# Altium schematic sheet SH4_BI-Gr_Potentiostat_D2AnA2D_R0.05.00.SchDoc(SH4_BI-Gr_Potentiostat_D2AnA2D_R0.05.00)
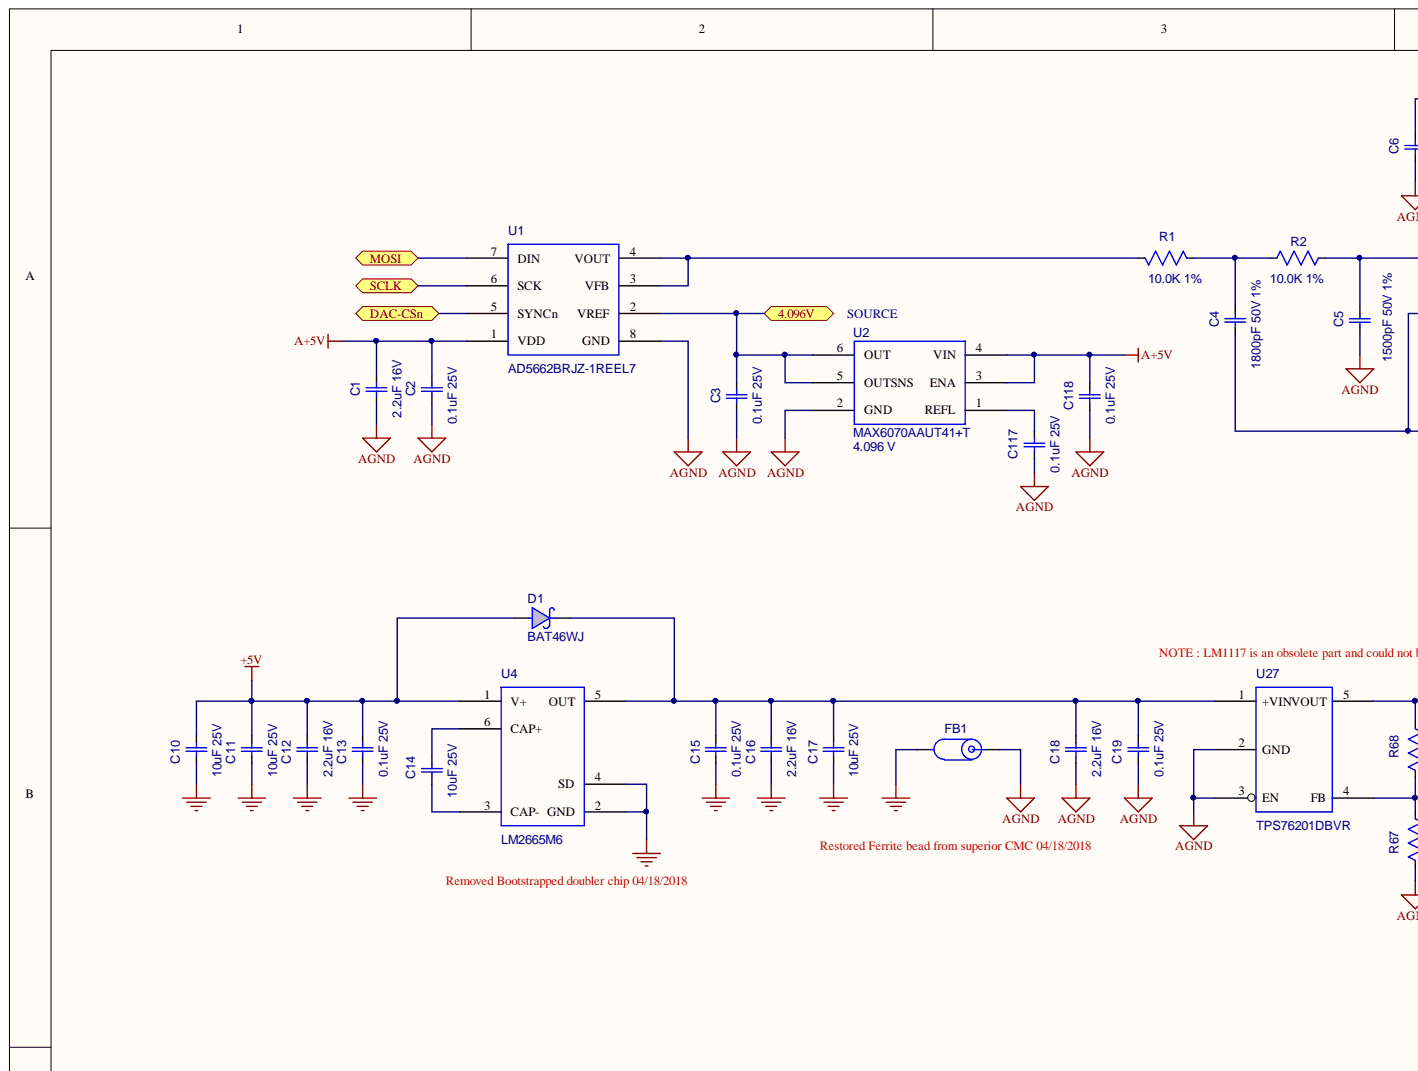
[diagram p0: SH4_BI-Gr_Potentiostat_D2AnA2D_R0.05.00.SchDoc, top left]
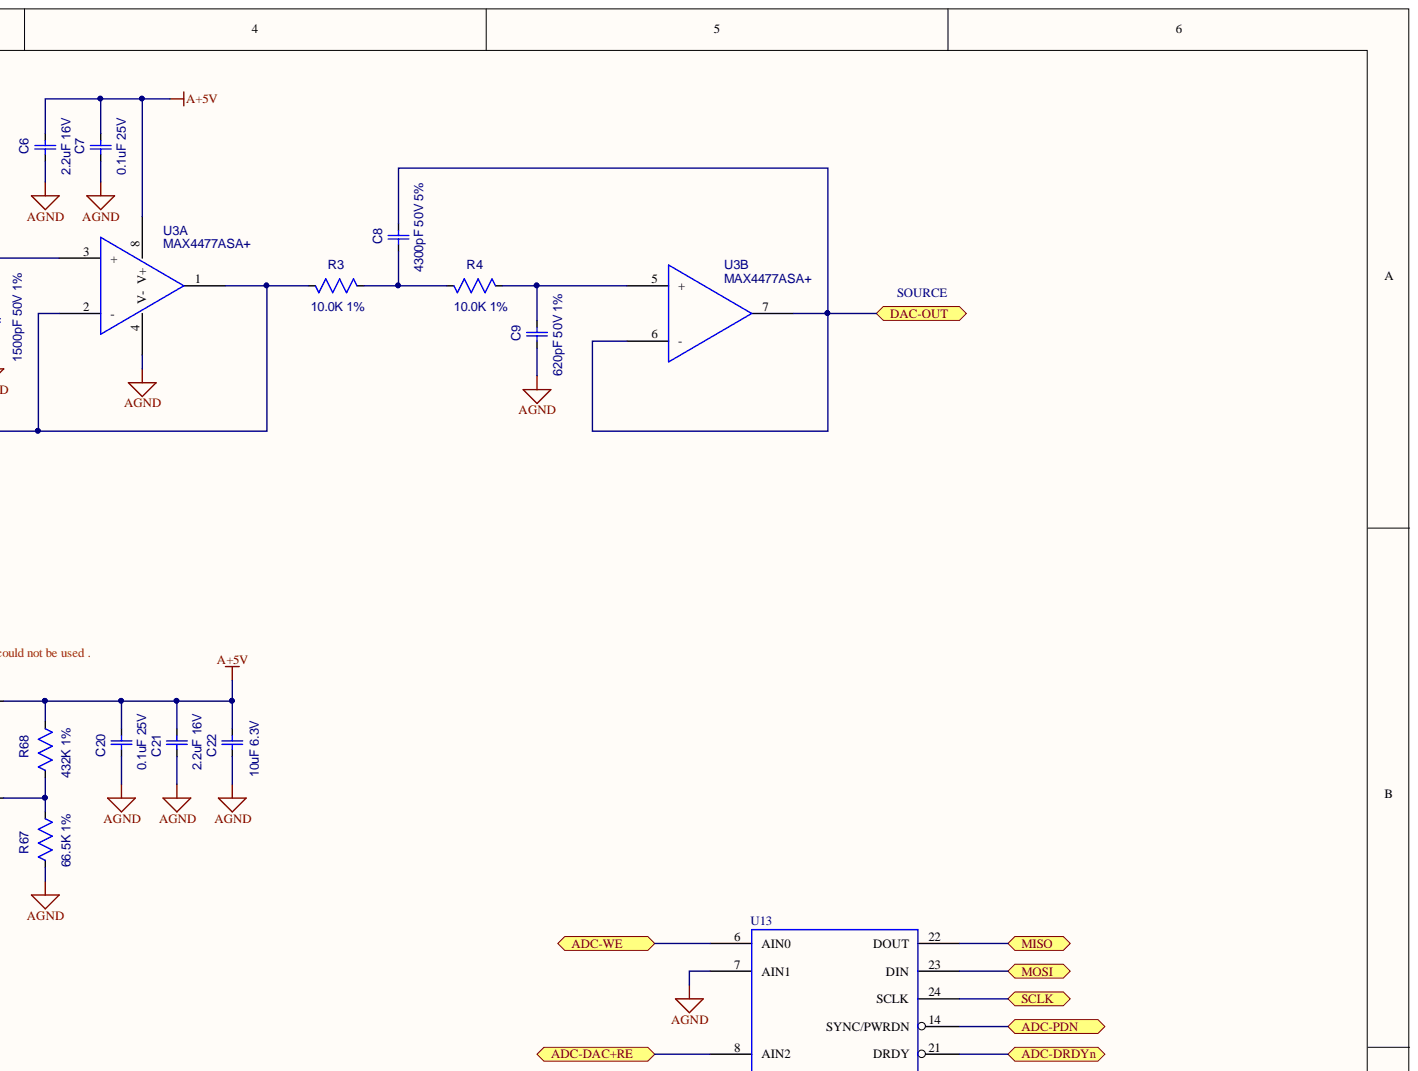
[diagram p1: SH4_BI-Gr_Potentiostat_D2AnA2D_R0.05.00.SchDoc, top right]
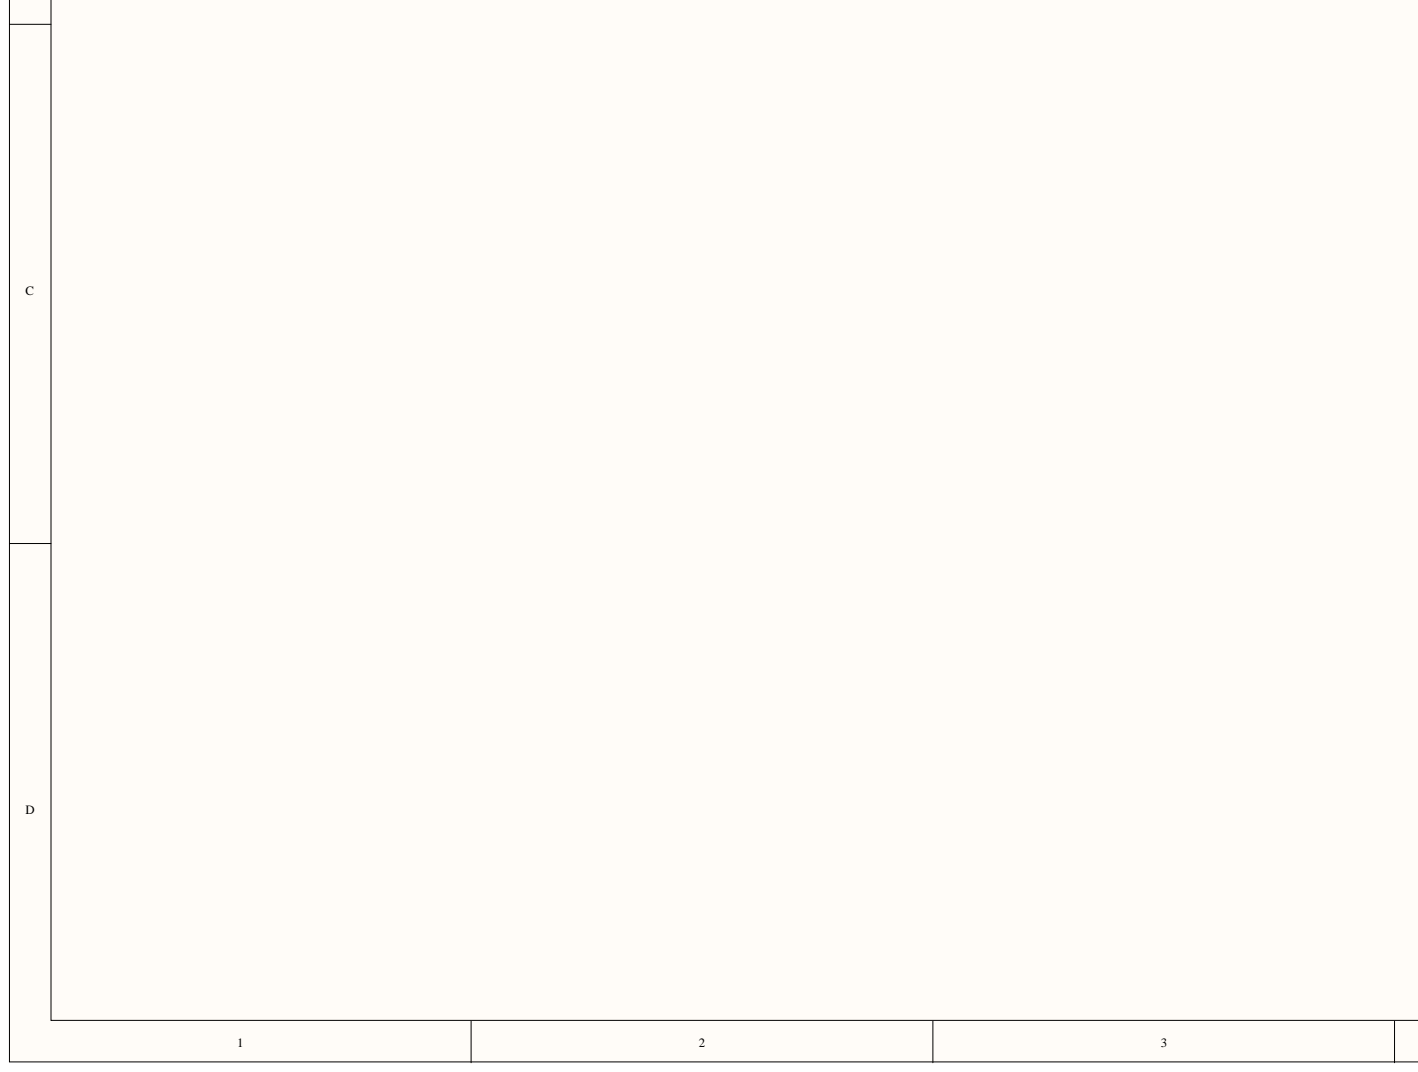
[diagram p2: SH4_BI-Gr_Potentiostat_D2AnA2D_R0.05.00.SchDoc, bottom left]
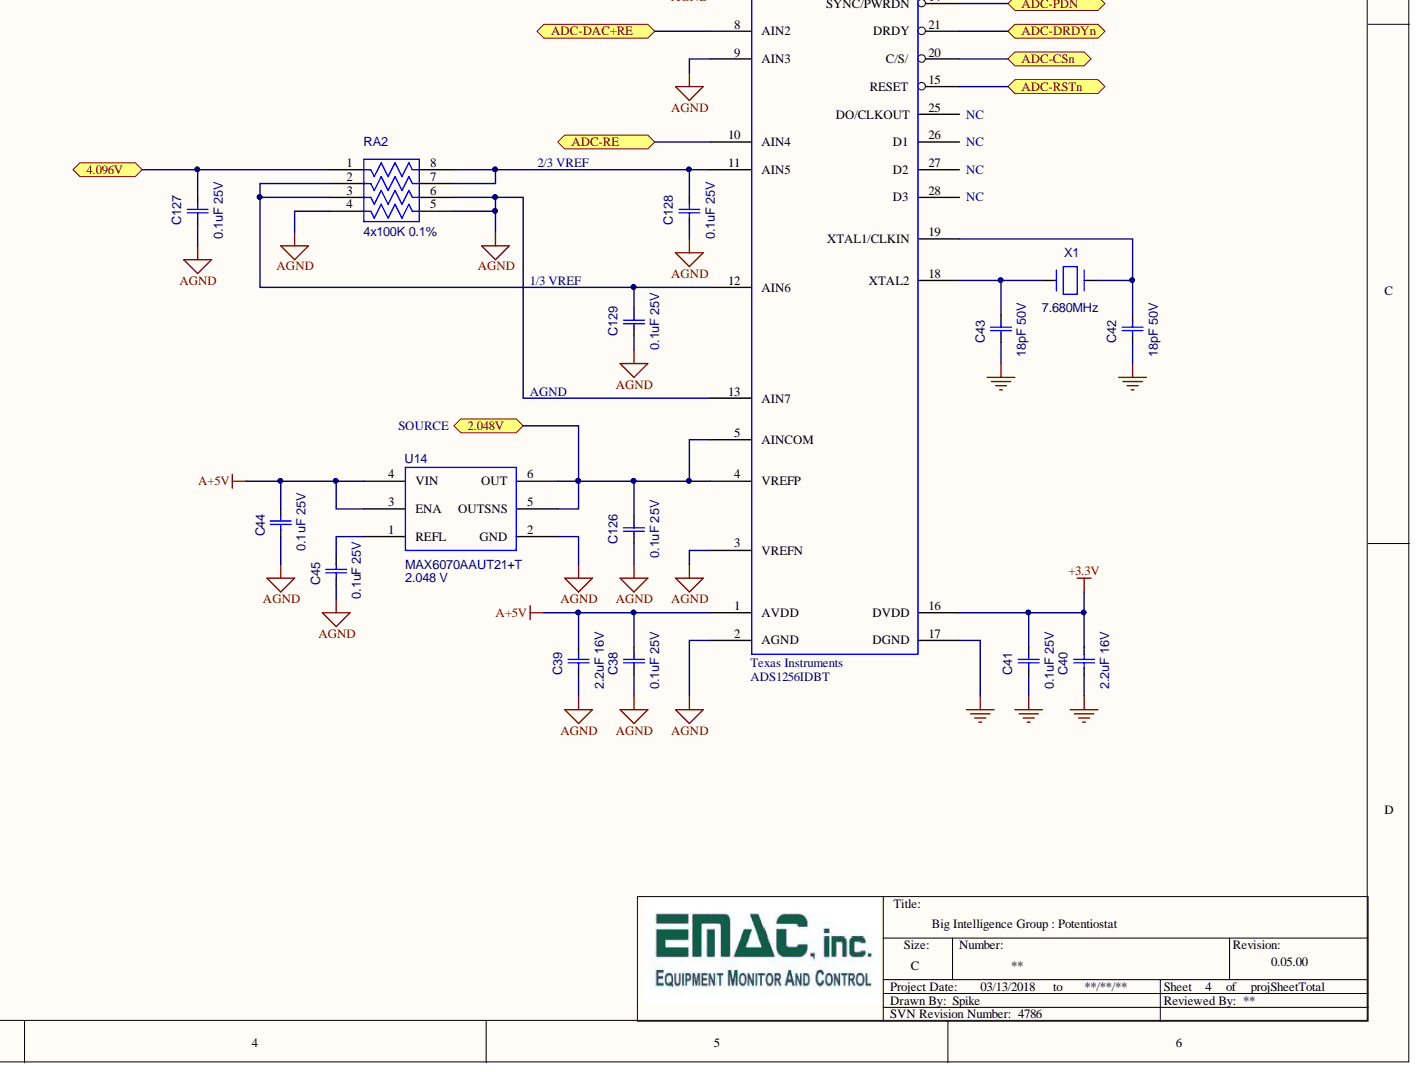
[diagram p3: SH4_BI-Gr_Potentiostat_D2AnA2D_R0.05.00.SchDoc, bottom right]

## Parts
C1  2.2uF 16V  value 2.2uF  Notes: 16V  [CC0603_IPC_L]
C2  0.1uF 25V  Notes: 25V  [CC0603_IPC_L]
C3  0.1uF 25V  Notes: 25V  [CC0603_IPC_L]
C4  1800pF 50V 1%  value 1800pF  Notes: 50V  [CC0603_IPC_L]
C5  1500pF 50V 1%  value 1500pF  Notes: 50V  [CC0603_IPC_L]
C6  2.2uF 16V  value 2.2uF  Notes: 16V  [CC0603_IPC_L]
C7  0.1uF 25V  Notes: 25V  [CC0603_IPC_L]
C8  4300pF 50V 5%  value 4300pF  Notes: 50V  [CC0603_IPC_L]
C9  620pF 50V 1%  value 620pF  Notes: 50V  [CC0603_IPC_L]
C10  10uF 25V  value 10uF  Notes: 25V  [CC0805_IPC_L]
C11  10uF 25V  value 10uF  Notes: 25V  [CC0805_IPC_L]
C12  2.2uF 16V  value 2.2uF  [CC0603_IPC_L]
C13  0.1uF 25V  Notes: 25V  [CC0603_IPC_L]
C14  10uF 25V  value 10uF  [CC0805_IPC_L]
C15  0.1uF 25V  Notes: 25V  [CC0603_IPC_L]
C16  2.2uF 16V  value 2.2uF  Notes: 16V  [CC0603_IPC_L]
C17  10uF 25V  value 10uF  Notes: 25V  [CC0805_IPC_L]
C18  2.2uF 16V  value 2.2uF  Notes: 16V  [CC0603_IPC_L]
C19  0.1uF 25V  Notes: 25V  [CC0603_IPC_L]
C20  0.1uF 25V  Notes: 25V  [CC0603_IPC_L]
C21  2.2uF 16V  value 2.2uF  Notes: 16V  [CC0603_IPC_L]
C22  10uF 6.3V  value 10uF  Notes: 6.3V  [CC0603_IPC_L]
C38  0.1uF 25V  Notes: 25V  [CC0603_IPC_L]
C39  2.2uF 16V  value 2.2uF  Notes: 16V  [CC0603_IPC_L]
C40  2.2uF 16V  value 2.2uF  Notes: 16V  [CC0603_IPC_L]
C41  0.1uF 25V  Notes: 25V  [CC0603_IPC_L]
C42  18pF 50V  value 18pF  Notes: 50V  [CC0603_IPC_L]
C43  18pF 50V  value 18pF  Notes: 50V  [CC0603_IPC_L]
C44  0.1uF 25V  Notes: 25V  [CC0603_IPC_L]
C45  0.1uF 25V  Notes: 25V  [CC0603_IPC_L]
C117  0.1uF 25V  Notes: 25V  [CC0603_IPC_L]
C118  0.1uF 25V  Notes: 25V  [CC0603_IPC_L]
C126  0.1uF 25V  Notes: 25V  [CC0603_IPC_L]
C127  0.1uF 25V  Notes: 25V  [CC0603_IPC_L]
C128  0.1uF 25V  Notes: 25V  [CC0603_IPC_L]
C129  0.1uF 25V  Notes: 25V  [CC0603_IPC_L]
D1  BAT46WJ  [DIO_BAT46WJ_SOD323]
FB1  RFchoke 4.0A  [LC0603_IPC_L]  (value not drawn)
R1  10.0K 1%  [RC0603_IPC_L]
R2  10.0K 1%  [RC0603_IPC_L]
R3  10.0K 1%  [RC0603_IPC_L]
R4  10.0K 1%  [RC0603_IPC_L]
R67  66.5K 1%  [RC0603_IPC_L]
R68  432K 1%  value 432K  [RC0603_IPC_L]
RA2  4x100K 0.1%  [SOIC127P607X173-8N]
U1  AD5662BRJZ-1REEL7  [SOT65P280X145-8AN]
U2  MAX6070AAUT41+T  value 4.096 V  [SOT23-6_MAX6070]
U3  MAX4477  Manufacturer PN: MAX4477ASA+  [SOIC127P600X175-8N]  (parts A,B)
U4  LM2665M6  [SOT95P280X145-6N]
U13  ADS1256IDBT  Manufacturer: Texas Instruments  [SSOP-28_ADS1256]
U14  MAX6070AAUT21+T  value 2.048 V  [SOT23-6_MAX6070]
U27  TPS76201DBVR  [SOT23_5_PAT1]
X1  7.680MHz  [HC-49_HCM49-8.000MABJ-UT]

## Ports
2.048V, 4.096V, ADC-CSn, ADC-DAC+RE, ADC-DRDYn, ADC-PDN, ADC-RE, ADC-RSTn, ADC-WE, DAC-CSn, DAC-OUT, MISO, MOSI, SCLK

## Nets
+3.3V: C40.1 C41.1 U13.16(DVDD)
+5V: C10.1 C11.1 C12.1 C13.1 D1.2 U4.1(V+)
A+5V: C1.1 C2.1 C6.1 C7.1 C20.1 C21.1 C22.1 C38.1 C39.1 C44.1 C118.1 R68.1 U1.1(VDD) U2.3(ENA) U2.4(VIN) U3.8(V+) U13.1(AVDD) U14.3(ENA) U14.4(VIN) U27.5(VOUT)
AGND: C1.2 C2.2 C3.2 C5.1 C6.2 C7.2 C9.2 C18.2 C19.2 C20.2 C21.2 C22.2 C38.2 C39.2 C44.2 C45.2 C117.2 C118.2 C126.2 C127.2 C128.2 C129.2 FB1.1 R67.2 RA2.4 RA2.5 RA2.6 U1.8(GND) U2.2(GND) U3.4(V-) U13.2(AGND) U13.3(VREFN) U13.7(AIN1) U13.9(AIN3) U13.13(AIN7) U14.2(GND) U27.2(GND) U27.3(EN)
GND: C10.2 C11.2 C12.2 C13.2 C15.2 C16.2 C17.2 C40.2 C41.2 C42.2 C43.2 FB1.2 U4.2(GND) U4.4(SD) U13.17(DGND)
2.048V: C126.1 U13.4(VREFP) U13.5(AINCOM) U14.5(OUTSNS) U14.6(OUT) ; port 2.048V
4.096V: C3.1 C127.1 RA2.1 U1.2(VREF) U2.5(OUTSNS) U2.6(OUT) ; port 4.096V
ADC-CSn: U13.20(C/S/) ; port ADC-CSn
ADC-DAC+RE: U13.8(AIN2) ; port ADC-DAC+RE
ADC-DRDYn: U13.21(DRDY) ; port ADC-DRDYn
ADC-PDN: U13.14(SYNC/PWRDN) ; port ADC-PDN
ADC-RE: U13.10(AIN4) ; port ADC-RE
ADC-RSTn: U13.15(RESET) ; port ADC-RSTn
ADC-WE: U13.6(AIN0) ; port ADC-WE
DAC-CSn: U1.5(SYNCn) ; port DAC-CSn
DAC-OUT: C8.1 U3.6(-) U3.7 ; port DAC-OUT
MISO: U13.22(DOUT) ; port MISO
MOSI: U1.7(DIN) U13.23(DIN) ; port MOSI
SCLK: U1.6(SCK) U13.24(SCLK) ; port SCLK
NetC4_1: C4.1 R1.1 R2.1
NetC4_2: C4.2 R3.2 U3.1 U3.2(-)
NetC5_2: C5.2 R2.2 U3.3(+)
NetC8_2: C8.2 R3.1 R4.2
NetC9_1: C9.1 R4.1 U3.5(+)
NetC14_1: C14.1 U4.6(CAP+)
NetC14_2: C14.2 U4.3(CAP-)
NetC15_1: C15.1 C16.1 C17.1 C18.1 C19.1 D1.1 U4.5(OUT) U27.1(+VIN)
NetC42_1: C42.1 U13.19(XTAL1/CLKIN) X1.1
NetC43_1: C43.1 U13.18(XTAL2) X1.2
NetC45_1: C45.1 U14.1(REFL)
NetC117_1: C117.1 U2.1(REFL)
NetC128_1: C128.1 RA2.7 RA2.8 U13.11(AIN5)
NetC129_1: C129.1 RA2.2 RA2.3 U13.12(AIN6)
NetR1_2: R1.2 U1.3(VFB) U1.4(VOUT)
NetR67_1: R67.1 R68.2 U27.4(FB)

Not connected: U13 25(DO/CLKOUT) 26(D1) 27(D2) 28(D3)

## Library data of the parts
C1 C6 C12 C16 C18 C21 C39 C40 = 09-90070: CCG^  2.2uF  16V 0603  10% X5R; type: S; SVN Revision No.: 5061; Status Code: L; Options: 00; Database Table Name: passives; Part Number: 09-90070; Package: 0603; msl: 1; Library Name: MySQL_ALTIUM.DbLib; Height Max (mm): 0.90 mm; Component Kind: Standard; Author: Mike L.
  C12: Value: 2.2uF; Notes: 16V
C2 C3 C7 C13 C15 C19 C20 C38 C41 C44 C45 C117 C118 C126-C129 = 09-90081: CCG^0.1uF   25V 0603   10% X7R; Manufacturer PN: C0603C104K3RAC7411; Manufacturer: Kemet; Value: .1 uF; type: S; Temp Range (°C): -55°C ~ 125°C; SVN Revision No.: 5061; Status Code: L; Options: 00; Database Table Name: passives; RoHS: YES; Part Number: 09-90081; Package: 0603; msl: 1; Library Name: MySQL_ALTIUM.DbLib; Height Max (mm): 0.90 mm; Component Kind: Standard; Author: Mike L.
C4 = 09-90182: CCG^1800pF 50V 1% COG NPO 0603; Manufacturer PN: GRM1885C1H182FA01D; Manufacturer: Murata Electronics North America; type: S; Temp Range (°C): -55°C ~ 125°C; SVN Revision No.: 5061; Status Code: N; Options: 00; Database Table Name: passives; RoHS: YES; Part Number: 09-90182; Package: 0603; msl: 1; Library Name: MySQL_ALTIUM.DbLib; Height Max (mm): 0.80 mm; Datasheet: https://www.mouser.com/ds/2/281/murata_03052018_GRM_Series_1-1310166.pdf; Component Kind: Standard; Author: TM
C5 = 09-90181: CCG^1500pF 50V 1% COG NPO 0603; Manufacturer PN: GCM1885C1H152FA16D  GCM1885C1H152FA16D; Manufacturer: Murata Electronics North America; type: S; Temp Range (°C): -55°C ~ 125°C; SVN Revision No.: 5061; Status Code: N; Options: 00; Database Table Name: passives; RoHS: YES; Part Number: 09-90181; Package: 0603; msl: 1; Library Name: MySQL_ALTIUM.DbLib; Height Max (mm): 0.80 mm; Datasheet: https://www.mouser.com/ds/2/281/GCM1885C1H152FA16-01-1064505.pdf; Component Kind: Standard; Author: TM
C8 = 09-90183: CCG^4300pF 50V 5% COG NPO 0603; Manufacturer PN: C0603C432J5GACTU; Manufacturer: KEMET; type: S; Temp Range (°C): -55°C ~ 125°C; SVN Revision No.: 5061; Status Code: N; Options: 00; Database Table Name: passives; RoHS: YES; Part Number: 09-90183; Package: 0603; msl: 1; Library Name: MySQL_ALTIUM.DbLib; Height Max (mm): 0.80 mm; Datasheet: https://www.mouser.com/ds/2/212/KEM_C1003_C0G_SMD-1101588.pdf; Component Kind: Standard; Author: TM
C9 = 09-90180: CCG^ 620pF 50V 1% COG NPO 0603; Manufacturer PN: C0603C621F5GACTU; Manufacturer: KEMET; type: S; Temp Range (°C): -55°C ~ 125°C; SVN Revision No.: 5061; Status Code: N; Options: 00; Database Table Name: passives; RoHS: YES; Part Number: 09-90180; Package: 0603; msl: 1; Library Name: MySQL_ALTIUM.DbLib; Height Max (mm): 0.80 mm; Datasheet: https://www.mouser.com/ds/2/212/KEM_C1003_C0G_SMD-1101588.pdf; Component Kind: Standard; Author: TM
C10 C11 C14 C17 = 09-90041: CCG^ 10uF 25V   0805   10% X5R; Manufacturer PN: TMK212BBJ106KG-T; Manufacturer: TAIYO YUDEN; type: S; Temp Range (°C): -55°C ~ 85°C; SVN Revision No.: 2227; Status Code: L; Options: 00; Database Table Name: passives; RoHS: YES; Part Number: 09-90041; Package: 0805; msl: 1; Library Name: MySQL_ALTIUM.DbLib; Last Updated: 5/21/15; Height Max (mm): 1.25 mm; Datasheet: http://www.yuden.co.jp/productdata/catalog/en/mlcc_all_e.pdf; Component Kind: Standard; Author: Mike L.
  C14: Value: 10uF; Notes: 25V
C22 = 09-90026: CCG^10uF   6.3V 0603   20% X5R; Manufacturer PN: C0603C106M9PACTU; Manufacturer: Kemet; type: S; Temp Range (°C): -55°C ~ 85°C; SVN Revision No.: 5061; Status Code: L; Options: 00; Database Table Name: passives; RoHS: YES; Part Number: 09-90026; Package: 0603; msl: 1; Library Name: MySQL_ALTIUM.DbLib; Height Max (mm): 0.90 mm; Datasheet: http://www.kemet.com/datasheets&C0603C106M9PACTU; Component Kind: Standard; Author: Mike L.
C42 C43 = 09-90031: CCG^18pF    50V 0603    5% C0G; Manufacturer PN: C0603C180J5GACTU; Manufacturer: Kemet; type: S; Temp Range (°C): -55°C ~ 125°C; SVN Revision No.: 5061; Status Code: L; Options: 00; Database Table Name: passives; RoHS: YES; Part Number: 09-90031; Package: 0603; msl: 1; Library Name: MySQL_ALTIUM.DbLib; Height Max (mm): 0.87 mm; Component Kind: Standard; Author: Mike L.
D1 = 04-90048: DSB^100V 0.25A BAT46WJ  SOD-32; Manufacturer PN: BAT46WJ,115; Manufacturer: NXP Semiconductors; Type: S; Temp Range (°C): -55°C ~ 150°C; Status Code: P; Options: 00; Notes: Vf=850mV @ 250mA, Vr=100V; Database Table Name: actives; ROHS: YES; Part Number: 04-90048; Package: SOD-323; MSL: 1; Library Name: MySQL_ALTIUM.DbLib; Height Max (mm): 0.80 mm; Datasheet: http://www.nxp.com/documents/data_sheet/BAT46WJ.pdf; Component Kind: Standard; Author: TM
FB1 = 08-90016: IBF^RFchoke 4.0A      SM 0603; Manufacturer PN: HI0603P600R-10; Manufacturer: Laird-Signal Integrity Products; type: S; SVN Revision No.: 2417; Status Code: L; Options: 00; Notes: Imax=4A, Rdc=30mOhm, Z=60Ohm@100MHz; Database Table Name: passives; RoHS: YES; Part Number: 08-90016; Package: 0603; msl: 1; Library Name: MySQL_ALTIUM.DbLib; Height Max (mm): 0.95 mm; Datasheet: http://www.lairdtech.com/WorkArea/linkit.aspx?LinkIdentifier=id&ItemID=4843; Component Kind: Standard; Author: Mike L.
R1-R4 = 10-90107: RCP_ 10.0K ohm 1% 0.062W  0603; Manufacturer PN: RC0603FR-0710KL; Manufacturer: Yageo; Temp Range (°C): -55°C ~ 155°C; SVN Revision No.: 3043; Status Code: L; Options: 00; Database Table Name: resistors; Value: 10.0K 1%; Type: S; RoHS: YES; Part Number: 10-90107; Package: 0603; MSL: 1; Library Name: MySQL_ALTIUM.DbLib; Height Max (mm): 0.55 mm; Datasheet: http://www.yageo.com.tw/exep/pages/download/literatures/PYu-R_INT-thick_7.pdf; Component Kind: Standard; Author: Mike L.
R67 = 10-90191: RCP^ 66.5K OHM 1% 0.100W  0603; SVN Revision No.: 3043; Status Code: L; Options: 00; Database Table Name: resistors; Value: 66.5K 1%; Type: S; Part Number: 10-90191; Package: 0603; MSL: 1; Library Name: MySQL_ALTIUM.DbLib; Height Max (mm): 0.55 mm; Component Kind: Standard; Author: Mike L.
R68 = 10-90460: RCP^ 432 Kohm 1% 0.100W 0603; Manufacturer PN: RC0603FR-07432KL; Manufacturer: Yageo; Temp Range (°C): -55°C ~ 155°C; SVN Revision No.: 3043; Status Code: N; Options: 00; Notes: Moisture Resistant; Database Table Name: resistors; Type: S; RoHS: YES; Part Number: 10-90460; Package: 0603; MSL: 1; Library Name: MySQL_ALTIUM.DbLib; Height Max (mm): 0.55 mm; Datasheet: http://www.yageo.com/documents/recent/PYu-RC_Group_51_RoHS_L_9.pdf; Component Kind: Standard; Author: TM
RA2 = 10-90454: RNI^4x100Kohm 0.1% 0.10W SOIC8; Manufacturer PN: AORN1003AT5; Manufacturer: Vishay; Temp Range (°C): -55°C ~ 155°C; SVN Revision No.: 4669; Status Code: N; Options: 00; Notes: AEC-Q200; Database Table Name: resistors; Value: 4x100K 0.1%; Type: S; RoHS: YES; Part Number: 10-90454; Package: SOIC-8; MSL: 1; Library Name: MySQL_ALTIUM.DbLib; Last Updated: 3/23/18; Height Max (mm): 1.73 mm; Datasheet: https://www.mouser.com/ds/2/427/aorn-537110.pdf; Component Kind: Standard; Author: JP
U1 = 06-90629: UAN^CV DAC 16bspi AD5562B SOT8; Manufacturer: Analog Devices Inc.; Temp Range (°C): -40°C ~ 125°C; SVN Revision No.: 4645; Status Code: N; Options: 00; Notes: Vs=2.7V~5.5V, 16bit, Ts=10us; Database Table Name: ics; Type: S; RoHS: YES; Part Number: 06-90629; Package: SOT23-8; MSL: 1; Manufacturer PN: AD5662BRJZ-1REEL7; Library Name: MySQL_ALTIUM.DbLib; Height Max (mm): 1.45 mm; Datasheet: http://www.analog.com/media/en/technical-documentation/data-sheets/AD5662.pdf; Component Kind: Standard; Author: TM
U2 = 06-90634: UAN^RE 4.096V MAX6070 SOT-23-6; Manufacturer: Maxim Integrated; Temp Range (°C): -40°C ~ 125°C; SVN Revision No.: 4650; Status Code: N; Options: 00; Notes: Vin=4.3V~5.5V, Vout=4.096V, Is=350uA, Iout=10mA; Database Table Name: ics; Type: S; RoHS: YES; Part Number: 06-90634; Package: SOT23-6; MSL: 1; Manufacturer PN: MAX6070AAUT41+T; Library Name: MySQL_ALTIUM.DbLib; Height Max (mm): 1.45 mm; Datasheet: https://datasheets.maximintegrated.com/en/ds/MAX6070-MAX6071.pdf; Component Kind: Standard; Author: TM
U3 = 06-90636: UAN^OA 2XRR 10Mz MAX4477 SOIC8; Manufacturer: Maxim Integrated; Temp Range (°C): -40°C ~ 125°C; SVN Revision No.: VersionControl; Status Code: N; Options: 00; Notes: Single Supply, Rail-to-Rail, Vs=2.7 V ~ 5.5 V, ±1.35 V ~ 2.75 V, GBP=10MHz, Io=48mA; Database Table Name: ics; Type: S; RoHS: YES; Part Number: 06-90636; Package: SOIC-8; MSL: 1; Library Name: MySQL_ALTIUM.DbLib; Last Updated: 3/22/18; Height Max (mm): 1.75 mm; Datasheet: https://datasheets.maximintegrated.com/en/ds/MAX4475-MAX4489.pdf; Component Kind: Standard; Author: JP
U4 = 06-90637: UAN^SR CapVl Dblr 40mA SOT23-6; Manufacturer PN: LM2665M6/NOPB; Manufacturer: Texas Instruments; Temp Range (°C): -40°C ~ 85°C; SVN Revision No.: VersionControl; Status Code: N; Options: 00; Notes: Vin=2.5V ~ 5.5V, Vout=2Vin, Vin/2, Is=650uA, Iout=40mA; Database Table Name: ics; Type: S; RoHS: YES; Part Number: 06-90637; Package: SOT23-6; MSL: 1; Library Name: MySQL_ALTIUM.DbLib; Height Max (mm): 1.45 mm; Datasheet: http://www.ti.com/lit/ds/symlink/lm2665.pdf; Component Kind: Standard; Author: TM
U13 = 06-90638: UAN^CV 8x24bit ADS1256  SSOP28; Temp Range (°C): -40°C ~ 85°C; SVN Revision No.: 4666; Status Code: N; Options: 00; Notes: DVDD=1.8V~3.6V, AVDD=5V, 24bit; Database Table Name: ics; Type: S; RoHS: YES; Part Number: 06-90638; Package: SSOP-28; MSL: 2; Manufacturer PN: ADS1256IDBT; Library Name: MySQL_ALTIUM.DbLib; Height Max (mm): 2.00 mm; Datasheet: http://www.ti.com/lit/ds/symlink/ads1255.pdf; Component Kind: Standard; Author: TM
U14 = 06-90633: UAN^RE 2.048V MAX6070 SOT-23-6; Manufacturer: Maxim Integrated; Temp Range (°C): -40°C ~ 125°C; SVN Revision No.: 4650; Status Code: N; Options: 00; Notes: Vin=2.7V~5.5V, Vout=2.048V, Is=260uA, Iout=10mA,; Database Table Name: ics; Type: S; RoHS: YES; Part Number: 06-90633; Package: SOT23-6; MSL: 1; Manufacturer PN: MAX6070AAUT21+T; Library Name: MySQL_ALTIUM.DbLib; Height Max (mm): 1.45 mm; Datasheet: https://datasheets.maximintegrated.com/en/ds/MAX6070-MAX6071.pdf; Component Kind: Standard; Author: TM
U27 = 06-90213: UAN^LR LOV/ULP ADJ     SOT23-5; Manufacturer: Texas Instruments; Temp Range (°C): -40°C ~ 125°C; SVN Revision No.: 558; Status Code: L; Options: 00; Notes: Vin=2.7 V ~ 10 V; Database Table Name: ics; Type: S; RoHS: YES; Part Number: 06-90213; Package: SOT-23-5; MSL: 1; Manufacturer PN: TPS76201DBVR; Library Name: MySQL_ALTIUM.DbLib; Last Updated: 2/20/17; Height Max (mm): 1.45 mm; Datasheet: http://www.ti.com/lit/ds/symlink/tps76201.pdf; Component Kind: Standard; Author: Mike L.
X1 = 14-90012: XQP 7.68Mhz 18 pF SMD HCM49; Manufacturer PN: HCM497680000ABJT; Manufacturer: Citizen Finedevice Co Ltd; Temp Range (°C): -40°C ~ 85°C; SVN Revision No.: VersionControl; Status Code: N; Options: 00; Notes: Freq=7.68MHz, CL=18pF, ESR=100?, Ft=±30ppm, Fs=±30ppm; Database Table Name: actives; Value: 7.680MHz; Type: S; ROHS: YES; Part Number: 14-90012; Package: HC49/US; MSL: 1; Library Name: MySQL_ALTIUM.DbLib; Height Max (mm): 4.30 mm; Datasheet: http://cfd.citizen.co.jp/english/prod-tech/product/pdf/datasheet_AT/HCM49_E.pdf; Component Kind: Standard; Author: TM
